# Altium schematic sheet PowerSupply.SchDoc
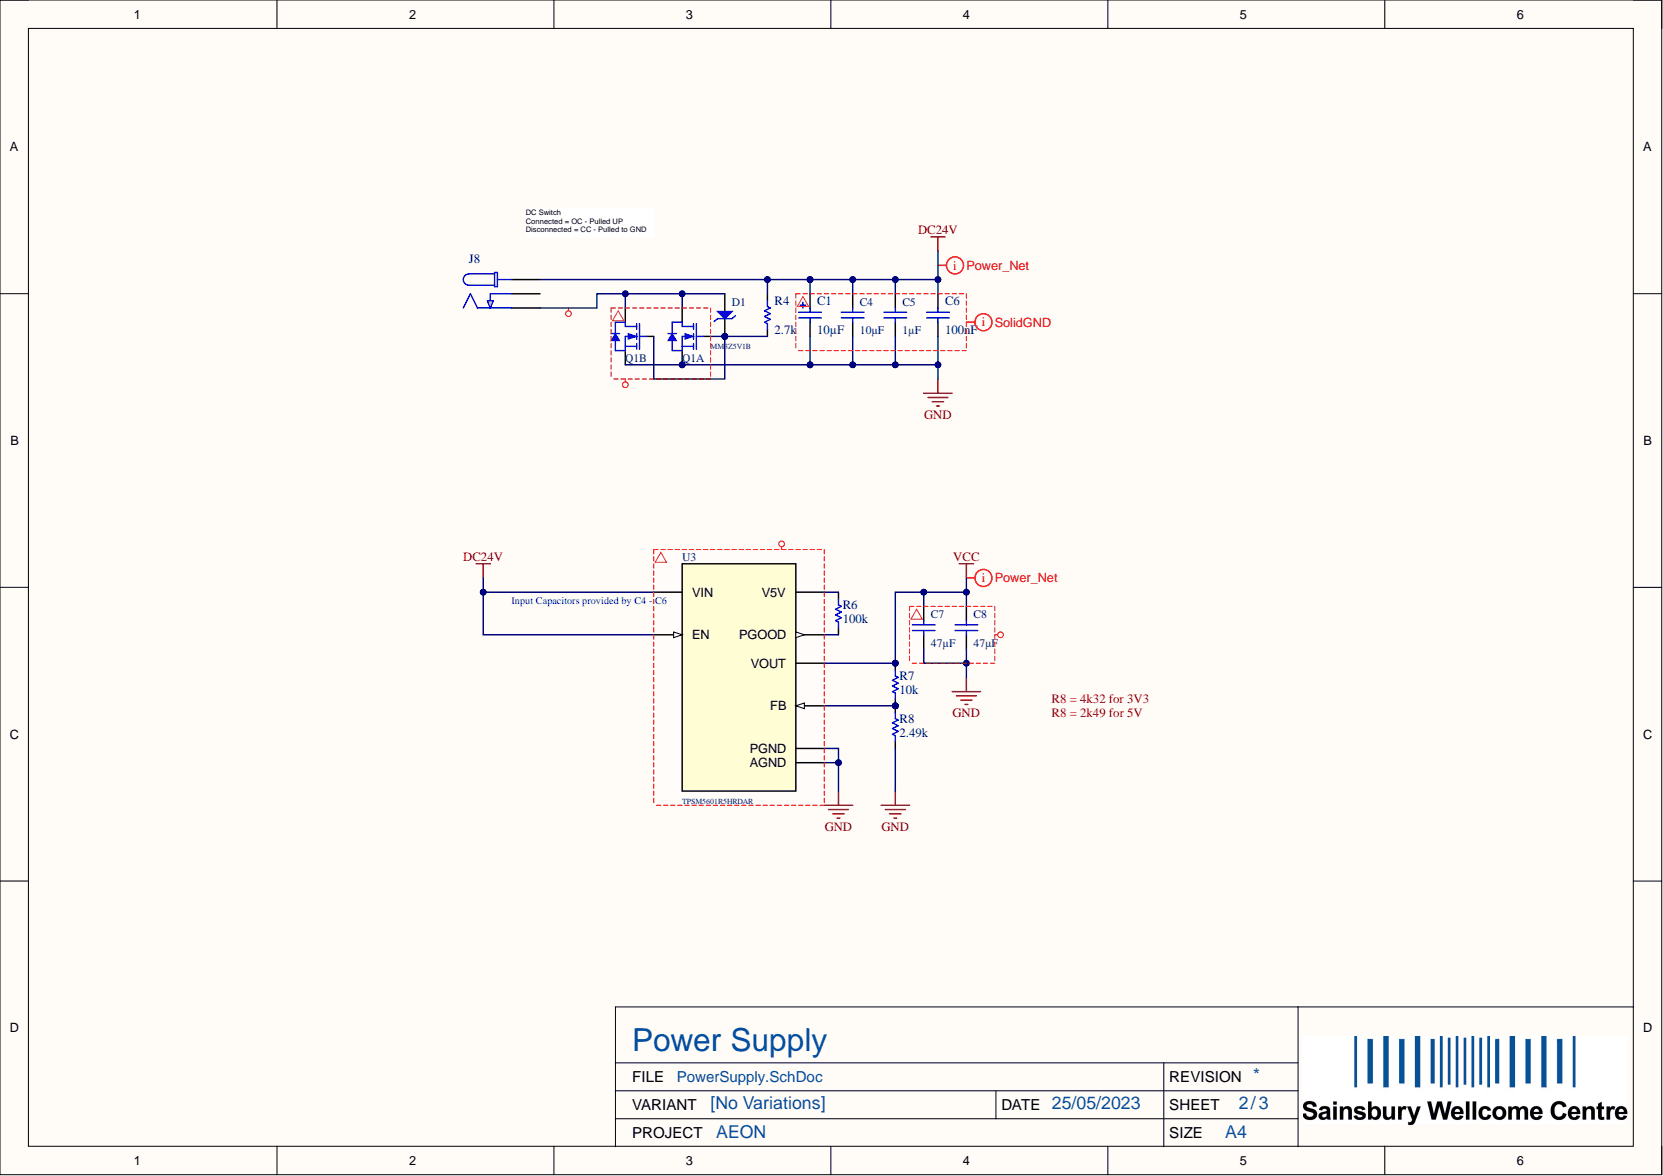
[diagram p0: PowerSupply.SchDoc]

## Parts
C1  value 10µF  [CAP_EEEFK1H100P]  (hidden comment: CPOLD-10uF-50V-20%-Alu)
C4  value 10µF  [CAPC3225X279X50NL20T25]  (hidden comment: C1210-10uF-50V-10%-X7R)
C5  value 1µF  [CAPC0805(2012)130_N]  (hidden comment: C0805-1uF-50V-10%-X7R)
C6  value 100nF  Capacitance: 100nF  [FP-GRM188-0_1-e0_2_0_5-IPC_B]  (hidden comment: C0603-100nF-100V-10%-X7R)
C7  value 47µF  [FP-GRM32E-0_3-0_2-IPC_B]  (hidden comment: C1210-47uF-10V-10%-X7R)
C8  value 47µF  [FP-GRM32E-0_3-0_2-IPC_B]  (hidden comment: C1210-47uF-10V-10%-X7R)
D1  MM3Z10VB  [SODFL250X100-2N]  (value not drawn)
J8  694108105102  [WE_694108105102]  (value not drawn)
Q1  FDMC8030  [TRANS_FDMC8030]  (value not drawn)  (parts A,B)
R4  value 2.7k  [FP-CPF0603-IPC_B]  (hidden comment: R0603-2k7-1/16W-0.1%)
R6  value 100k  Resistance: 100k  [FP-CPF0603-IPC_B]  (hidden comment: R0603-100k-1/16W-0.1%)
R7  value 10k  Resistance: 10k  [FP-CPF0603-IPC_B]  (hidden comment: R0603-10k-1/16W-0.1%)
R8  value 2.49k  Resistance: 2.49k  [FP-CPF0603-IPC_B]  (hidden comment: R0603-2k49-1/16W-0.1%)
U3  TPSM5601R5HRDAR  [IC_TPSM5601R5HRDAR]

## Nets
DC24V: C1.1 C4.2 C5.2 C6.2 J8.1 R4.2 U3.1(VIN) U3.2(EN)
GND: C1.2 C4.1 C5.1 C6.1 C7.1 C8.1 Q1.4 Q1.5 R8.1 U3.10(AGND) U3.15(PGND)
VCC: C7.2 C8.2 R7.2 U3.7(VOUT)
NetD1_1: D1.1 J8.2 Q1.9 Q1.10
NetD1_2: D1.2 Q1.1 Q1.8 R4.1
NetR6_1: R6.1 U3.12(PGOOD)
NetR6_2: R6.2 U3.11(V5V)
NetR7_1: R7.1 R8.2 U3.9(FB)

Not connected: J8 3

## Library data of the parts
C1 = CMP-612-0001-7: 10µF ±20% 50V SMD Aluminum Electrolytic Capacitor Surface Mount D; Capacitance: 10uF; ESR (Equivalent Series Resistance): 880mR; Lead Diameter: 0.65mm; Leakage Current: 3uA; Life (Hours): 2000Hour; Pick&PlaceFootprint: CPOLD; Polarity: Polar; Ripple Current: 165mA; Capacitor Type: Electrolytic; Case/Package: CPOLD; Component Kind: Standard; Construction: Cylindrical; Contact Plating: Tin; Diameter: 6.3mm; Height: 5.8mm; Height - Seated (Max): 0.24inch; Impedance: 880mR; Lead Free: Lead Free; Lead Pitch: 1.8mm; Length: 5.8mm; Max Operating Temperature: 105°C; Min Operating Temperature: -55°C; Mount: Surface Mount,PCB; Number of Pins: 2; Package Quantity: 1000; Packaging: Tape and Reel; Radiation Hardening: No; REACH SVHC: No SVHC; Ripple Current (AC): 165mA; RoHS: Yes; RoHS Compliant: Yes; Series: FK; Size: 5.8mm; Termination: SMD/SMT; Tolerance: 20%; Voltage: 50V; Voltage Rating: 50V; Voltage Rating (DC): 50V; Width: 7.8mm; Library Name: Sainsbury Wellcome Centre
C4 = CMP-611-0024-5: 10uF ±10% 50V Ceramic Capacitor X7R 1210 (3225 Metric); Pick&PlaceFootprint: C1210; Capacitance: 10uF; Capacitor Type: Ceramic; Case/Package: 1210; Component Kind: Standard; Dielectric: X7R; Mount: Surface Mount; RoHS: Yes; Tolerance: 10%; Voltage Rating: 50V; Library Name: Sainsbury Wellcome Centre
C5 = CMP-611-0023-3: 1uF ±10% 50V Ceramic Capacitor X7R 0805 (2012 Metric); Pick&PlaceFootprint: C0805; Capacitance: 1uF; Capacitor Type: Ceramic; Case Code (Imperial): 0805; Case Code (Metric): 2012; Case/Package: 0805; Component Kind: Standard; Mount: SMD; RoHS: Yes; Tolerance: 10%; Voltage Rating: 50V; Library Name: Sainsbury Wellcome Centre
C6 = CMP-611-0027-15: 0.1µF ±10% 100V Ceramic Capacitor X7R 0603 (1608 Metric); Pick&PlaceFootprint: 0603_CAP; Capacitor Type: Ceramic; Case Code (Imperial): 0603; Case Code (Metric): 1608; Case/Package: 0603; Component Kind: Standard; Construction: Flat; Depth: 0.8mm; Dielectric: X7R; Height: 0.8mm; Lead Free: Lead Free; Length: 1.6mm; Material: Ceramic; Max Operating Temperature: 125°C; Min Operating Temperature: -55°C; Mount: Surface Mount; Package Quantity: 1; Packaging: Tape and Reel; Radiation Hardening: No; REACH SVHC: No SVHC; RoHS: Yes; RoHS Compliant: Yes; Series: GRM; Size: 0.8mm; Termination: SMD/SMT; Thickness: 0.035inch; Tolerance: 10%; Voltage: 100V; Voltage Rating: 100V; Voltage Rating (DC): 100V; Weight: 0.000222oz; Width: 0.8mm; Library Name: Sainsbury Wellcome Centre
C7 C8 = CMP-611-0031-10: 47uF ±10% 10V Ceramic Capacitor X7R 1210 (3225 Metric); Pick&PlaceFootprint: C1210; Capacitance: 47uF; Capacitor Type: Ceramic; Case Code (Imperial): 1210; Case Code (Metric): 3225; Case/Package: 1210; Component Kind: Standard; Construction: Flat; Depth: 2.5mm; Dielectric: X7R; Height: 2.5mm; Lead Free: Lead Free; Length: 3.2mm; Material: Ceramic; Max Operating Temperature: 125°C; Min Operating Temperature: -55°C; Mount: Surface Mount; Number of Pins: 2; Package Quantity: 1; Packaging: Tape and Reel; Radiation Hardening: No; REACH SVHC: No SVHC; RoHS: Yes; RoHS Compliant: Yes; Series: GRM; Termination: SMD/SMT; Thickness: 0.106inch; Tolerance: 10%; Voltage: 10V; Voltage Rating: 10V; Voltage Rating (DC): 10V; Width: 2.5mm; Library Name: Sainsbury Wellcome Centre
D1 = CMP-822-0001-1: DIODE ZENER 10V 200MW SOD323F; PartNumber: MM3Z5V1B; Element Configuration: Single; ESD Protection: No; Forward Voltage: 1V; Impedance: 18R; Max Reverse Current: 180nA; Peak Reverse Current: 1.8uA; Test Current: 5mA; Tolerance: 2%; Component Kind: Standard; Contact Plating: Tin, Matte; Height: 1mm; Lead Free: Lead Free; Length: 1.3mm; Max Operating Temperature: 150°C; Max Power Dissipation: 200mW; Max Reverse Leakage Current: 180nA; Min Operating Temperature: -65°C; Mount: Surface Mount; Number of Pins: 2; Package Quantity: 1; Packaging: Tape and Reel; Power Dissipation: 200mW; Radiation Hardening: No; REACH SVHC: No SVHC; RoHS Compliant: Yes; Voltage Tolerance: 2%; Weight: 0.0276g; Width: 1.7mm; Working Voltage: 10V; Zener Voltage: 10V; Library Name: Sainsbury Wellcome Centre
J8 = CMP-360-0003-3: DC Power Connectors WR-DC PwrJk CtrPnSMT Right Angled W/Peg; Connector Type: Jack,Power; Current Rating: 5A; Gender: Male; Insulation Resistance: 100MR; Shielding: Unshielded; Voltage Rating: 24V; Color: Black; Component Kind: Standard; Contact Gender: Female; Contact Material: Copper Alloy; Contact Plating: Tin; Flammability Rating: UL94 V-0; Housing Material: Polyamide; Max Operating Temperature: 85°C; Min Operating Temperature: -40°C; Mount: Surface Mount; Package Quantity: 200; Packaging: Tape and Reel; REACH SVHC: Unknown; Termination: SMD/SMT; Voltage Rating (DC): 24V; Library Name: Sainsbury Wellcome Centre
Q1 = CMP-830-0003-2: ON SEMICONDUCTOR - FDMC8030 - Dual MOSFET, Dual N Channel, 12 A, 40 V, 0.008 ohm, 10 V, 1.5 V; Continuous Drain Current (ID): 12A; Drain to Source Breakdown Voltage: 40V; Drain to Source Resistance: 10mR; Fall Time: 3ns; FET Type: 2 N-Channel; Gate to Source Voltage (Vgs): 12V; Input Capacitance: 1.975nF; Max Power Dissipation: 800mW; Number of Elements: 1; Polarity: N-CHANNEL; Turn-Off Delay Time: 19ns; Turn-On Delay Time: 7ns; Component Kind: Standard; Drain to Source Voltage (Vdss): 40V; Element Configuration: Dual; Height: 0.75mm; Length: 3mm; Max Operating Temperature: 150°C; Min Operating Temperature: -55°C; Mount: Surface Mount; Number of Pins: 8; Package Quantity: 3000; Packaging: Tape and Reel; Power Dissipation: 800mW; Radiation Hardening: No; Rds On Max: 10mR; Rise Time: 3ns; RoHS Compliant: Yes; Series: PowerTrench®; Weight: 0.196g; Width: 3mm; Library Name: Sainsbury Wellcome Centre
R4 = CMP-633-0031-14: Surface Mount Resistor 2k7 Ohm 1/16 W 0.1% 0603 (1608 Metric); Max Power Dissipation: 62.5 mW; Number of Resistors: 1; Pick&PlaceFootprint: R0603; Power Rating: 62.5mW; Resistance: 2.7k; Temperature Coefficient: 25ppm/°C; Value: 2.7k; Voltage Rating: 50V; Case Code (Imperial): 0603; Case Code (Metric): 1608; Case/Package: 0603; Component Kind: Standard; Composition: Thin Film; ELV: Compliant; Height: 0.45mm; Length: 1.6mm; Max Operating Temperature: 155°C; Min Operating Temperature: -55°C; Mount: Surface Mount; Number of Pins: 2; Number of Terminations: 2; Packaging: Tape and Reel; REACH SVHC: No SVHC; Resistance Tolerance: 0.1%; Resistor Type: Thin Film; RoHS: Yes; RoHS Compliant: Yes; Series: CPF; Size Code: 0603; Technology: Thin Film; Termination: Solder; Tolerance: 0.1%; Voltage Rating (DC): 50V; Weight: 7.1E-05oz; Width: 0.8mm; Library Name: Sainsbury Wellcome Centre
R6 = CMP-633-0000-17: Surface Mount Resistor 100k Ohm 1/16 W 0.1% 0603 (1608 Metric); Max Power Dissipation: 62.5 mW; Number of Resistors: 1; Pick&PlaceFootprint: R0603; Power Rating: 62.5mW; Temperature Coefficient: 25ppm/°C; Voltage Rating: 50V; Case Code (Imperial): 0603; Case Code (Metric): 1608; Case/Package: 0603; Component Kind: Standard; Composition: Thin Film; ELV: Compliant; Height: 0.45mm; Length: 1.6mm; Max Operating Temperature: 155°C; Min Operating Temperature: -55°C; Mount: Surface Mount; Number of Pins: 2; Number of Terminations: 2; Packaging: Tape and Reel; REACH SVHC: No SVHC; Resistance Tolerance: 0.1%; Resistor Type: Thin Film; RoHS: Yes; RoHS Compliant: Yes; Series: CPF; Size Code: 0603; Technology: Thin Film; Termination: Solder; Tolerance: 0.1%; Voltage Rating (DC): 50V; Weight: 7.1E-05oz; Width: 0.8mm; Library Name: Sainsbury Wellcome Centre
R7 = CMP-633-0002-15: Surface Mount Resistor 10k Ohm 1/16 W 0.1% 0603 (1608 Metric); Max Power Dissipation: 62.5 mW; Number of Resistors: 1; Pick&PlaceFootprint: R0603; Power Rating: 62.5mW; Temperature Coefficient: 25ppm/°C; Voltage Rating: 50V; Case Code (Imperial): 0603; Case Code (Metric): 1608; Case/Package: 0603; Component Kind: Standard; Composition: Thin Film; ELV: Compliant; Height: 0.45mm; Length: 1.6mm; Max Operating Temperature: 155°C; Min Operating Temperature: -55°C; Mount: Surface Mount; Number of Pins: 2; Number of Terminations: 2; Packaging: Tape and Reel; REACH SVHC: No SVHC; Resistance Tolerance: 0.1%; Resistor Type: Thin Film; RoHS: Yes; RoHS Compliant: Yes; Series: CPF; Size Code: 0603; Technology: Thin Film; Termination: Solder; Tolerance: 0.1%; Voltage Rating (DC): 50V; Weight: 7.1E-05oz; Width: 0.8mm; Library Name: Sainsbury Wellcome Centre
R8 = CMP-633-0082-1: Surface Mount Resistor 2.49k Ohm 1/16 W 0.1% 0603 (1608 Metric); Max Power Dissipation: 62.5 mW; Number of Resistors: 1; Pick&PlaceFootprint: R0603; Power Rating: 62.5mW; Temperature Coefficient: 25ppm/°C; Voltage Rating: 50V; Case Code (Imperial): 0603; Case Code (Metric): 1608; Case/Package: 0603; Component Kind: Standard; Composition: Thin Film; Construction: Chip; ELV: Compliant; Height: 0.45mm; Length: 1.6mm; Max Operating Temperature: 155°C; Min Operating Temperature: -55°C; Mount: Surface Mount; Number of Pins: 2; Number of Terminations: 2; Packaging: Tape and Reel; REACH SVHC: Yes; Resistance Tolerance: 0.1%; Resistor Type: FIXED RESISTOR; RoHS: Yes; RoHS Compliant: Yes; Series: CPF; Size Code: 0603; Technology: THIN FILM; Termination: Wraparound; Tolerance: 0.1%; Voltage Rating (DC): 50V; Weight: 7.1E-05oz; Width: 0.8mm; Library Name: Sainsbury Wellcome Centre
U3 = CMP-A10-0005-5: 60-V input, 1-V to 16-V output, 1.5-A power module in enhanced HotRod™ QFN package 15-B3QFN -40 to 125; Pick&PlaceFootprint: B3QFN; Component Kind: Standard; PartNumber: TPSM5601R5HRDAR; Library Name: Sainsbury Wellcome Centre
